# Altium schematic sheet Schematic.SchDoc(Schematic)
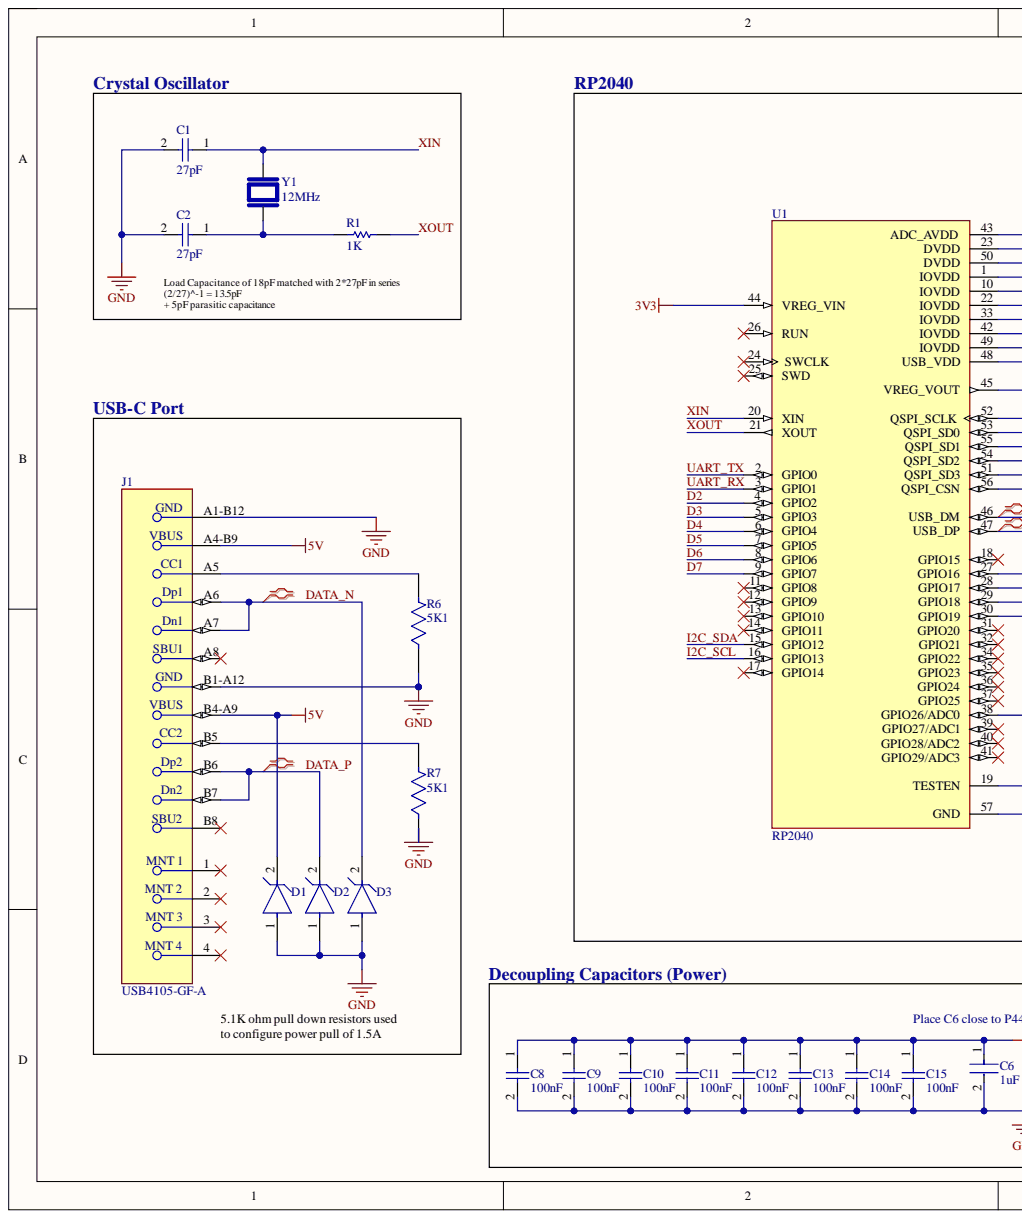
[diagram p0: Schematic.SchDoc, left]
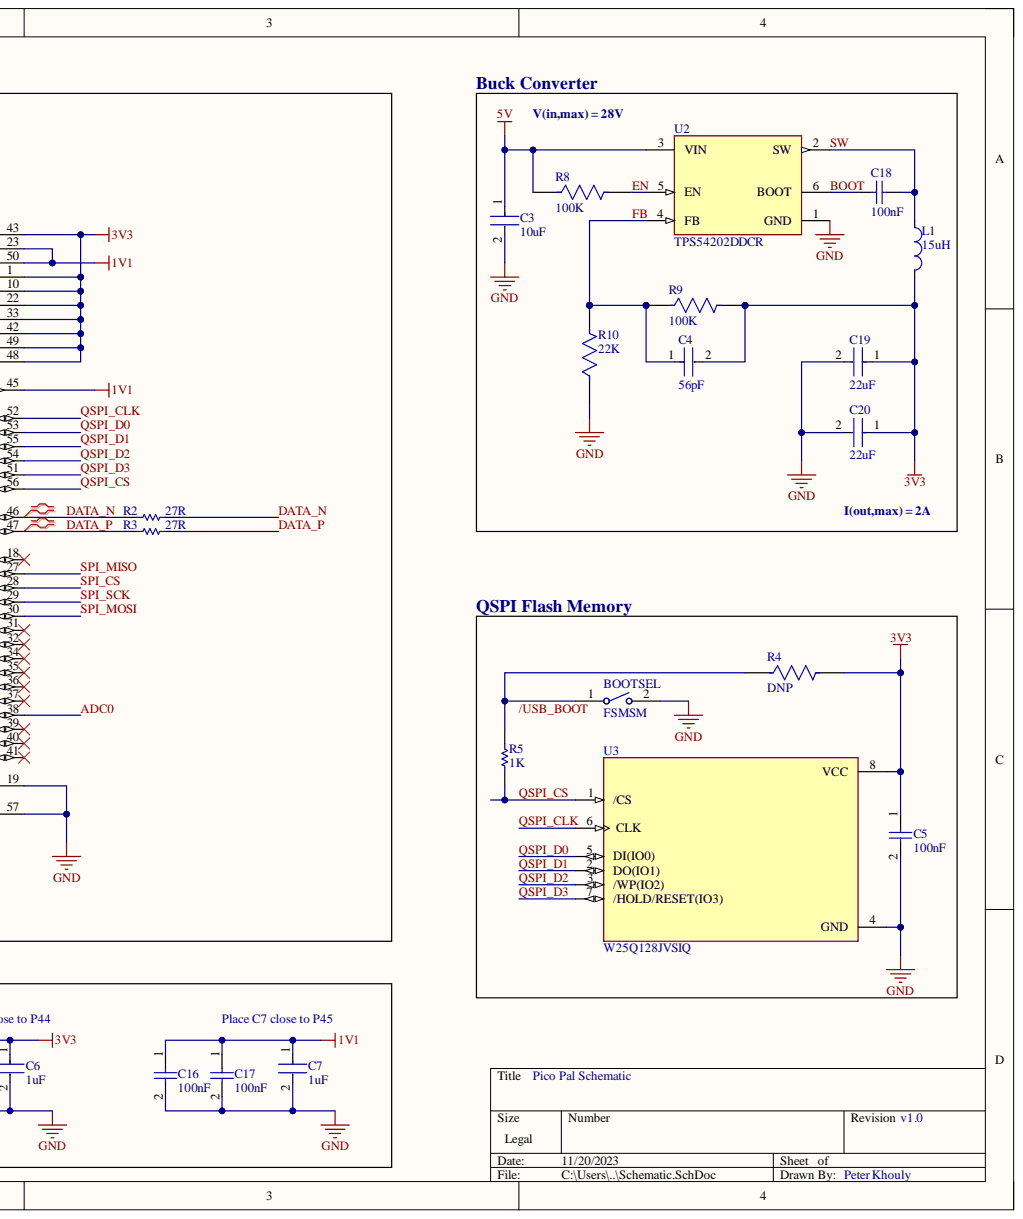
[diagram p1: Schematic.SchDoc, right]

## Parts
BOOTSEL  FSMSM  [TECO-FSMSM-2_V]
C1  27pF  [CAPC1005X55X25LL05T10]
C2  27pF  [CAPC1005X55X25LL05T10]
C3  10uF  [FP-GRM15-0_2-MFG]
C4  56pF  [FP-0402-L_1_0_1-W_0_5_0_1-MFG]
C5  100nF  [CAPC1005X56X25LL10T15]
C6  1uF  [FP-GRM15-0_1-MFG]
C7  1uF  [FP-GRM15-0_1-MFG]
C8  100nF  [CAPC1005X56X25LL10T15]
C9  100nF  [CAPC1005X56X25LL10T15]
C10  100nF  [CAPC1005X56X25LL10T15]
C11  100nF  [CAPC1005X56X25LL10T15]
C12  100nF  [CAPC1005X56X25LL10T15]
C13  100nF  [CAPC1005X56X25LL10T15]
C14  100nF  [CAPC1005X56X25LL10T15]
C15  100nF  [CAPC1005X56X25LL10T15]
C16  100nF  [CAPC1005X56X25LL10T15]
C17  100nF  [CAPC1005X56X25LL10T15]
C18  100nF  [CAPC1005X56X25LL10T15]
C19  22uF  [FP-CL746-IPC_C]
C20  22uF  [FP-CL746-IPC_C]
D1  (no value)  [FP-SLP1006P2-MFG]
D2  (no value)  [FP-SLP1006P2-MFG]
D3  (no value)  [FP-SLP1006P2-MFG]
J1  USB4105-GF-A  [FP-USB4105-GF-A-MFG]
L1  15uH  [FP-MLZ2012-1_25-IPC_B]
R1  1K  [RESC1005X40X25NL05T05]
R2  27R  [RESC1005X40X25LL05T05]
R3  27R  [RESC1005X40X25LL05T05]
R4  DNP  [FP-CRCW1206-e3-IPC_C]
R5  1K  [RESC1005X40X25NL05T05]
R6  5K1  [FP-ERJ2RK-IPC_A]
R7  5K1  [FP-ERJ2RK-IPC_A]
R8  100K  [FP-ERJ2RK-IPC_C]
R9  100K  [FP-ERJ2RK-IPC_C]
R10  22K  [FP-ERJ2RK-MFG]
U1  RP2040  [QFN40P700X700X90-57N]
U2  TPS54202DDCR  Max Input Voltage: 28V  [FP-DDC0006A-IPC_B]
U3  W25Q128JVSIQ  [SOIC127P790X216-8N]
Y1  12MHz  [XTAL_ABLS-12.000MHZ-B4-T]

## Nets
1V1: C7.1 C16.1 C17.1 U1.23(DVDD) U1.45(VREG_VOUT) U1.50(DVDD)
3V3: C4.2 C5.1 C6.1 C8.1 C9.1 C10.1 C11.1 C12.1 C13.1 C14.1 C15.1 C19.1 C20.1 L1.2 R4.2 R9.2 U1.1(IOVDD) U1.10(IOVDD) U1.22(IOVDD) U1.33(IOVDD) U1.42(IOVDD) U1.43(ADC_AVDD) U1.44(VREG_VIN) U1.48(USB_VDD) U1.49(IOVDD) U3.8(VCC)
5V: C3.1 D1.2 J1.A4-B9 J1.B4-A9 R8.1 U2.3(VIN)
GND: BOOTSEL.2 C1.2 C2.2 C3.2 C5.2 C6.2 C7.2 C8.2 C9.2 C10.2 C11.2 C12.2 C13.2 C14.2 C15.2 C16.2 C17.2 C19.2 C20.2 D1.1 D2.1 D3.1 J1.A1-B12 J1.B1-A12 R6.1 R7.1 R10.1 U1.19(TESTEN) U1.57(GND) U2.1(GND) U3.4(GND)
ADC0: U1.38(GPIO26/ADC0)
BOOT: C18.2 U2.6(BOOT)
D2: U1.4(GPIO2)
D3: U1.5(GPIO3)
D4: U1.6(GPIO4)
D5: U1.7(GPIO5)
D6: U1.8(GPIO6)
D7: U1.9(GPIO7)
DATA_N: D3.2 J1.A6 J1.A7 R2.1 R2.2 U1.46(USB_DM)
DATA_P: D2.2 J1.B6 J1.B7 R3.1 R3.2 U1.47(USB_DP)
EN: R8.2 U2.5(EN)
FB: C4.1 R9.1 R10.2 U2.4(FB)
I2C_SCL: U1.16(GPIO13)
I2C_SDA: U1.15(GPIO12)
QSPI_CLK: U1.52(QSPI_SCLK) U3.6(CLK)
QSPI_CS: R5.1 U1.56(QSPI_CSN) U3.1(/CS)
QSPI_D0: U1.53(QSPI_SD0) U3.5(DI(IO0))
QSPI_D1: U1.55(QSPI_SD1) U3.2(DO(IO1))
QSPI_D2: U1.54(QSPI_SD2) U3.3(/WP(IO2))
QSPI_D3: U1.51(QSPI_SD3) U3.7(/HOLD/RESET(IO3))
SPI_CS: U1.28(GPIO17)
SPI_MISO: U1.27(GPIO16)
SPI_MOSI: U1.30(GPIO19)
SPI_SCK: U1.29(GPIO18)
SW: C18.1 L1.1 U2.2(SW)
UART_RX: U1.3(GPIO1)
UART_TX: U1.2(GPIO0)
XIN: C1.1 U1.20(XIN) Y1.2
XOUT: R1.2 U1.21(XOUT)
NetBOOTSEL_1: BOOTSEL.1 R4.1 R5.2
NetC2_1: C2.1 R1.1 Y1.1
NetJ1_A5: J1.A5 R6.2
NetJ1_B5: J1.B5 R7.2
/USB_BOOT:

Not connected: J1 1 2 3 4 A8 B8; U1 11(GPIO8) 12(GPIO9) 13(GPIO10) 14(GPIO11) 17(GPIO14) 18(GPIO15) 24(SWCLK) 25(SWD) 26(RUN) 31(GPIO20) 32(GPIO21) 34(GPIO22) 35(GPIO23) 36(GPIO24) 37(GPIO25) 39(GPIO27/ADC1) 40(GPIO28/ADC2) 41(GPIO29/ADC3)

## Library data of the parts
BOOTSEL = CMP-2000-07511-1: FSMSM Push Button Switch, 50 mA, -35 to 85 degC, 2-Pin SMD, RoHS, Bulk; Manufacturer: TE Connectivity; Actuator Length: 1.07mm; Actuator Material: Polyamide; Case\/Package: SMD/SMT; Contact Current Rating: 50mA; Contact Resistance: 100mOhm; Electrical Life: 20000Cycles; Illumination Type: Illuminated; Max Voltage Rating (DC): 12V; Operating Force: 180gf; Switch Travel: 0.25mm; Throw Configuration: SPST; Voltage Rating (DC): 24V; Component Kind: Standard; Contact Material: Brass; Contact Plating: Silver; Current Rating: 50mA; Datasheet URL: http://www.te.com/commerce/DocumentDelivery/DDEController?Action=showdoc&DocId=Customer+Drawing%7F1437566-3%7FC2%7Fpdf%7FEnglish%7FENG_CD_1437566-3_C2_1437566_3_1_c.dwg.pdf%7F1437566-3; Datasheet Version: Rev. C2, 08/2014; ELV: Compliant; Lead Free: LeadFree; Library Name: Altium Content Vault; Manufacturer URL: http://www.te.com/; Max Operating Temperature: 85degC; Mechanical Life: 20000Cycles; Min Operating Temperature: -35degC; Mount: SurfaceMount; Mounting Technology: SurfaceMount; Orientation: Straight; Package Description: 2-Pin Surface Mount Device, Body 6 x 3.5 mm, Pitch 9.19 mm; Package Quantity: 1000; Package Reference: FSMSM; Packaging: LoosePiece; REACH SVHC: Unknown; RoHSCompliant: true; Termination: GullWing
C1 C2 = CMP-2008-03917-1: Manufacturer: Murata; Part Number: GRM1555C1H270JA01D; Component Kind: Standard; Library Name: Altium Content Vault
C3 = CMP-06035-000074-1: CAP CER 10UF 6.3V X6S 0402; Manufacturer: Murata; Manufacturer Part Number: GRM155C80J106ME11D; Material: Ceramic; Temperature Coefficient: 22%; Tolerance: 20%; Automotive: No; Capacitance: 10uF; Category: Cap; Component Kind: Standard; ComponentLink2Description: Datasheet; ComponentLink2URL: https://search.murata.co.jp/Ceramy/image/img/A01X/G101/ENG/GRM155C80J106ME11-01.pdf; Device Class L1: Passive Components; Device Class L2: Capacitors; Device Class L3: Ceramic Capacitors; Digikey Description: CAP CER 10UF 6.3V X6S 0402; Height: 0.7mm; Ipc Land Pattern Name: CAPC10050X50; Lead Free: Yes; Library Name: Altium Content Vault; Mouser Description: Cap Ceramic 10uF 6.3V X6S 20% Pad SMD 0402 105C T/R; Octopart: https://octopart.com/grm155c80j106me11d-murata-71220993; Package: GRM15-0.2; RoHS: TRUE; Temperature: -55°C to +105°C; Temperature Characteristic: X6S; Temperature Range High: +105°C; Temperature Range Low: -55°C; Value: 10uF; Voltage Rating: 6.3V
C4 = CMP-2008-02663-2: General Purpose Ceramic Capacitor, 0402, 56pF, 5%, C0G, 30ppm/°C, 50V; Capacitance: 56pF; Case Code (Imperial): 0402; Case Code (Metric): 1005; Case/Package: 0402; Component Kind: Standard; Construction: Flat; Contact Plating: Tin; Depth: 0.5mm; Dielectric: C0G; Dielectric Material: Ceramic; Height: 0.5mm; Lead Free: Lead Free; Length: 1mm; Library Name: Altium Content Vault; Max Operating Temperature: 125°C; Min Operating Temperature: -55°C; Mount: Surface Mount; Number of Pins: 2; Package Quantity: 1; Packaging: Tape and Reel; Radiation Hardening: No; REACH SVHC: No SVHC; RoHS Compliant: Yes; Series: -; Temperature Coefficient: 0ppm/°C; Termination: SMD/SMT; Thickness: 0.022inch; Tolerance: 5%; Voltage: 50V; Voltage Rating: 50V; Voltage Rating (DC): 50V; Width: 0.02inch
C5 C8-C18 = CMP-2008-02743-1: Manufacturer: AVX Interconnect / Elco; Part Number: 04026D104KAT2A; Component Kind: Standard; Library Name: Altium Content Vault
C6 C7 = CMP-2008-04556-2: CAP CER 1UF 10V X5R 0402; Manufacturer: Murata; Manufacturer Part Number: GRM155R61A105KE15D; Material: Ceramic; Temperature Coefficient: 15%; Tolerance: 10%; Automotive: No; Capacitance: 1uF; Category: Cap; Component Kind: Standard; ComponentLink2Description: Datasheet; ComponentLink2URL: https://search.murata.co.jp/Ceramy/image/img/A01X/G101/ENG/GRM155R61A105KE15-01A.pdf; Device Class L1: Passive Components; Device Class L2: Capacitors; Device Class L3: Ceramic Capacitors; Digikey Description: CAP CER 1UF 10V X5R 0402; Height: 0.55mm; Ipc Land Pattern Name: CAPC10050X50; Lead Free: Yes; Library Name: Altium Content Vault; Mouser Description: 0402 1 uF 10 V ±10% Tolerance X5R Surface Mount Multilayer Ceramic Capacitor; Octopart: https://octopart.com/grm155r61a105ke15d-murata-197442; Package: GRM15-0.1; RoHS: TRUE; Temperature: -55°C to +85°C; Temperature Characteristic: X5R; Temperature Range High: +85°C; Temperature Range Low: -55°C; Value: 1uF; Voltage Rating: 10V
C19 C20 = CMP-13271-000286-1: Cap Ceramic 22uF 6.3V X5R ±20% SMD 0402 +85°C Paper T/R; Capacitance: 22uF; Case Code (Imperial): 0402; Case Code (Metric): 1005; Case/Package: 0402; Component Kind: Standard; Length: 0.039inch; Library Name: Altium Content Vault; Max Operating Temperature: 85°C; Min Operating Temperature: -55°C; Mount: Surface Mount; Packaging: Tape and Reel; Thickness: 0.022inch; Tolerance: 20%; Voltage Rating: 6.3V; Width: 0.02inch
D1-D3 = CMP-56445-000005-1: TVS DIODE 33VWM 60VC SLP1006P2; Clamping Voltage: 60V; Diode Type: ZENER; Direction: Unidirectional; Element Configuration: Single; Leakage Current: 1uA; Max Breakdown Voltage: 45V; Min Breakdown Voltage: 36V; Number of Unidirectional Channels: 1; Peak Pulse Current: 2A; Peak Pulse Power: 125W; Power Dissipation: 170W; Power Line Protection: No; Reverse Standoff Voltage: 33V; Case Code (Imperial): 0402; Case Code (Metric): 1006; Case/Package: SMD/SMT; Clamping Voltage-Max: 60V; Component Kind: Standard; Depth: 0.6mm; Lead Free: Lead Free; Length: 1mm; Library Name: Altium Content Vault; Max Operating Temperature: 125°C; Min Operating Temperature: -40°C; Mount: Surface Mount; Number of Pins: 2; Packaging: Tape and Reel; Radiation Hardening: No; Resistor Type: Zener; RoHS Compliant: Yes; Series: Automotive, AEC-Q100, uClamp®; Working Voltage: 33V
J1 = CMP-245163-000001-1: CONN RCPT USB2.0 TYPEC 5A; Manufacturer: GCT; Conn Orientation: Right Angle; Current Rating: 5/6.25/1.25/0.25A; Is Female: yes; Number Of Contacts: 16; Voltage Rating: 20V; Automotive: No; Category: Conn; Component Kind: Standard; ComponentLink2Description: Datasheet; ComponentLink2URL: https://gct.co/files/drawings/usb4105.pdf; Contact Material: Gold,Nickel; Device Class L1: Connectors; Device Class L2: USB Connectors; Device Class L3: unset; Digikey Description: CONN RCPT USB2.0 TYPEC 5A; Height: 3.46mm; Lead Free: Yes; Library Name: Altium Content Vault; Manufacturer Part Number: USB4105-GF-A; Octopart: https://octopart.com/usb4105-gf-a-gct-106627878; Package: 0; RoHS: TRUE; Temperature: -40°C to +85°C; Temperature Range High: +85°C; Temperature Range Low: -40°C; Usb Standard: USB 2.0
L1 = CMP-08253-000108-1: Shielded Multilayer Inductor 15uH ±20% 350mA 611mΩ SMD 0805; Core Material: Ferrite; DC Resistance (DCR): 611mR; Saturation Current: 90mA; Self Resonant Frequency: 40MHz; Test Frequency: 2MHz; Case Code (Imperial): 0805; Case Code (Metric): 2012; Case/Package: 0805; Component Kind: Standard; Current Rating: 350mA; Height - Seated (Max): 0.057inch; Inductance: 15uH; Length: 0.079inch; Library Name: Altium Content Vault; Max Operating Temperature: 125°C; Min Operating Temperature: -55°C; Mount: Surface Mount; Package Quantity: 1; Packaging: Tape and Reel; RoHS Compliant: Yes; Shielding: Shielded; Tolerance: 20%; Width: 0.049inch
R1 R5 = CMP-1011-00510-4: Chip Resistor, 1 KOhm, +/- 1%, 100 mW, -55 to 155 degC, 0402 (1005 Metric), RoHS, Tape and Reel; Manufacturer: Basic Passives; PartNumber: ERJ-2RKF1001X; Case\/Package: 0402; Power Rating: 100 mW; Resistance: 1 KOhm; Voltage Rating: 50 V; Case Code (Imperial): 0402; Case Code (Metric): 1005; Component Kind: Standard; ComponentLink1Description: Manufacturer URL; ComponentLink1URL: http://www.panasonic.com/; ComponentLink2Description: Datasheet; ComponentLink2URL: http://industrial.panasonic.com/cdbs/www-data/pdf/RDA0000/AOA0000C86.pdf; DatasheetVersion: 04/2015; Height: 0.35 mm; Length: 1 mm; Library Name: Altium Content Vault; Max Operating Temperature: 155 degC; Min Operating Temperature: -55 degC; Mount: Surface Mount; Mounting Technology: Surface Mount; Package Quantity: 10000; PackageDescription: 2-Pin Surface Mount Device, Body 1 x 0.5 mm; PackageReference: 0402; Packaging: Tape and Reel; Pins: 2; Radiation Hardening: No; REACH SVHC: Yes; RoHSCompliant: true; Tolerance: 1%; Width: 0.5 mm
R2 R3 = CMP-2002-00498-1: Manufacturer: Panasonic; Part Number: ERJ-2GEJ270X; Component Kind: Standard; Library Name: Altium Content Vault
R4 = CMP-2003-04807-2: RES Thick Film, 10kΩ, 1%, 0.25W, 100ppm/°C, 1206; Max Power Dissipation: 250mW; Resistance: 10kR; Voltage Rating: 200V; Case Code (Imperial): 1206; Case Code (Metric): 3216; Case/Package: 1206; Component Kind: Standard; Composition: Thick Film; Contact Plating: Tin; Depth: 1.6mm; Features: Automotive AEC-Q200; Height: 0.55mm; Lead Free: Lead Free; Length: 3.2mm; Library Name: Altium Content Vault; Max Operating Temperature: 155°C; Military Standard: Not; Min Operating Temperature: -55°C; Number of Pins: 2; Number of Terminations: 2; Package Quantity: 10; Packaging: Tape and Reel; Power Rating: 250mW; Radiation Hardening: No; Temperature Coefficient: 100ppm/°C; Termination: SMD/SMT; Tolerance: 1%; Voltage Rating (DC): 200V; Weight: 0.000353oz; Width: 0.063inch; Working Voltage: 200V
R6 R7 = CMP-2002-01158-2: RES SMD 5.1K OHM 1% 1/10W 0402; Max Power Dissipation: 100mW; Resistance: 5.1kR; Temperature Coefficient: 100ppm/°C; Voltage Rating: 50V; Case Code (Imperial): 0402; Case Code (Metric): 1005; Case/Package: 0402; Component Kind: Standard; Construction: Rectangular; Contact Plating: Tin; Depth: 0.5mm; Height: 0.35mm; Lead Free: Lead Free; Length: 1mm; Library Name: Altium Content Vault; Max Operating Temperature: 155°C; Military Standard: Not; Min Operating Temperature: -55°C; Mount: Surface Mount; Number of Terminations: 2; Package Quantity: 10000; Packaging: Tape and Reel; Power Rating: 100mW; REACH SVHC: No SVHC; Resistance Tolerance: 1%; Resistor Type: Thick Film; RoHS Compliant: Yes; Series: ERJ; Technology: THICK FILM; Termination: SMD/SMT; Tolerance: 1%; Voltage Rating (DC): 50V; Weight: 2.8E-05oz; Width: 0.5mm
R8 R9 = CMP-2002-00608-4: RES SMD 100K OHM 1% 1/10W 0402; Max Power Dissipation: 100mW; Resistance: 100kR; Temperature Coefficient: 100ppm/°C; Voltage Rating: 50V; Case Code (Imperial): 0402; Case Code (Metric): 1005; Case/Package: 0402; Component Kind: Standard; Composition: Thick Film; Contact Plating: Tin; Depth: 0.5mm; Features: Automotive AEC-Q200; Height: 0.35mm; Lead Free: Lead Free; Length: 1mm; Library Name: Altium Content Vault; Max Operating Temperature: 155°C; Military Standard: Not; Min Operating Temperature: -55°C; Mount: Surface Mount; Number of Pins: 2; Number of Terminations: 2; Package Quantity: 1; Packaging: Tape and Reel; Power Rating: 100mW; Radiation Hardening: No; REACH SVHC: No SVHC; Termination: SMD/SMT; Tolerance: 1%; Voltage Rating (DC): 50V; Width: 0.5mm
R10 = CMP-2002-01133-2: RES SMD 22K OHM 1% 1/10W 0402; Max Power Dissipation: 100mW; Resistance: 22kR; Temperature Coefficient: 100ppm/°C; Voltage Rating: 50V; Case Code (Imperial): 0402; Case Code (Metric): 1005; Case/Package: 0402; Component Kind: Standard; Composition: Thick Film; Contact Plating: Tin; Depth: 0.5mm; Height: 0.35mm; Lead Free: Lead Free; Length: 1mm; Library Name: Altium Content Vault; Max Operating Temperature: 155°C; Military Standard: Not; Min Operating Temperature: -55°C; Mount: Surface Mount; Number of Pins: 2; Number of Terminations: 2; Package Quantity: 10000; Packaging: Tape and Reel; Power Rating: 100mW; Radiation Hardening: No; REACH SVHC: No SVHC; Termination: SMD/SMT; Tolerance: 1%; Voltage Rating (DC): 50V; Width: 0.02inch
U1 = RP2040: RP2040 chip for Raspberry Pi Pico - ARMÂ® CortexÂ®-M0+ MCU 32-Bit; Availability: In Stock; Check_prices: https://www.snapeda.com/parts/RP2040/Raspberry+Pi/view-part/?ref=eda; Component Kind: Standard; Library Name: RP2040.IntLib; MF: Raspberry Pi; MP: RP2040; Package: None; Price: None; Purchase-URL: https://pricing.snapeda.com/search/part/RP2040/?ref=eda; SnapEDA_Link: https://www.snapeda.com/parts/RP2040/Raspberry+Pi/view-part/?ref=snap
U2 = CMP-04918-000439-1: IC REG BUCK ADJ 2A TSOT23-6; Min Input Voltage: 4.5V; Min Output Voltage: 596mV; Number of Outputs: 1; Output Configuration: Positive; Output Type: Adjustable; Quiescent Current: 40uA; Switching Frequency: 500kHz; Synchronous Rectifier: Yes; Case/Package: SOT-23-6; Component Kind: Standard; Lead Free: Lead Free; Library Name: Altium Content Vault; Max Operating Temperature: 125°C; Max Output Voltage: 28V; Min Operating Temperature: -40°C; Mount: Surface Mount; Output Current: 2A; Packaging: Tape and Reel; RoHS Compliant: Yes
U3 = W25Q128JVSIQ: FLASH - NOR Memory IC 128Mb (16M x 8) SPI - Quad I/O, QPI, DTR 133 MHz 8-SOIC; MF: Winbond Electronics; Availability: In Stock; Check_prices: https://www.snapeda.com/parts/W25Q128JVSIQ/Winbond+Electronics/view-part/?ref=eda; Component Kind: Standard; Library Name: W25Q128JVSIQ.IntLib; MP: W25Q128JVSIQ; Package: SOIC-8 Winbond; Price: None; Purchase-URL: https://pricing.snapeda.com/search/part/W25Q128JVSIQ/?ref=eda; SnapEDA_Link: https://www.snapeda.com/parts/W25Q128JVSIQ/Winbond+Electronics/view-part/?ref=snap
Y1 = ABLS-12.000MHZ-B4-T: HC/49US (AT49) SMD LOW PROFILE CRYSTAL; MF: Abracon LLC; Availability: In Stock; Check_prices: https://www.snapeda.com/parts/ABLS-12.000MHZ-B4-T/Abracon+LLC/view-part/?ref=eda; Component Kind: Standard; Library Name: ABLS-12.000MHZ-B4-T.IntLib; MP: ABLS-12.000MHZ-B4-T; Package: HC-49/US Abracon LLC; Price: None; Purchase-URL: https://pricing.snapeda.com/search/part/ABLS-12.000MHZ-B4-T/?ref=eda; SnapEDA_Link: https://www.snapeda.com/parts/ABLS-12.000MHZ-B4-T/Abracon+LLC/view-part/?ref=snap
